# Altium schematic sheet Base IC.SchDoc
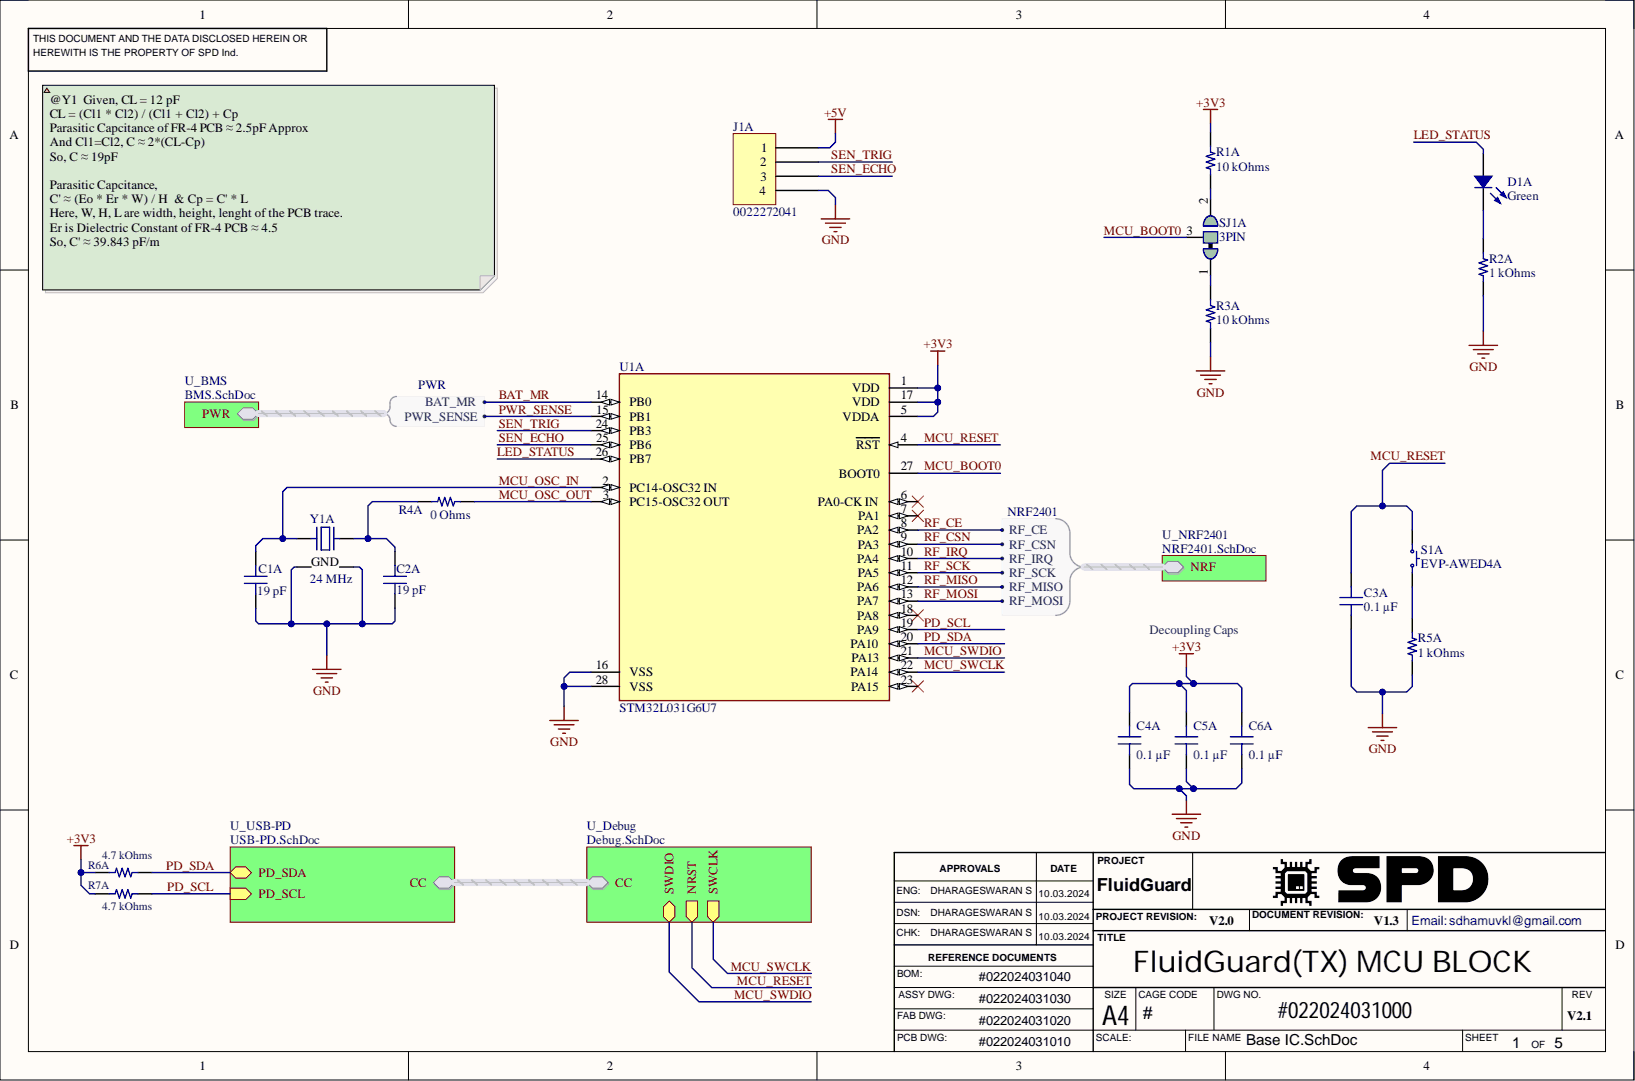
[diagram p0: Base IC.SchDoc]

## Parts
C1A  19 pF  [CAP 0402_1005]
C2A  19 pF  [CAP 0402_1005]
C3A  0.1 µF  [CAP 0402_1005]
C4A  0.1 µF  [CAP 0402_1005]
C5A  0.1 µF  [CAP 0402_1005]
C6A  0.1 µF  [CAP 0402_1005]
D1A  Green  [LED 0402_1005 GREEN]
J1A  0022272041  [MOLEX KK 0022272041]
R1A  10 kOhms  [RES 0402_1005]
R2A  1 kOhms  [RES 0402_1005]
R3A  10 kOhms  [RES 0402_1005]
R4A  0 Ohms  [RES 0402_1005]
R5A  1 kOhms  [RES 0402_1005]
R6A  4.7 kOhms  [RES 0402_1005]
R7A  4.7 kOhms  [RES 0402_1005]
S1A  EVP-AWED4A  [PANASONIC EVPAW]
SJ1A  3PIN  [Rounded Jumper Joint 3-pin]
U1A  STM32L031G6U7  [FP-UFQFPN28-A0B0-MFG]
Y1A  24 MHz  [EPSON TSX-3225]

## Nets
+3V3: C4A.2 C5A.2 C6A.2 R1A.2 R6A.2 R7A.2 U1A.1(VDD) U1A.5(VDDA) U1A.17(VDD)
+5V: J1A.1
GND: C1A.1 C2A.1 C3A.1 C4A.1 C5A.1 C6A.1 J1A.4 R2A.1 R3A.1 R5A.1 U1A.16(VSS) U1A.28(VSS) Y1A.2 Y1A.4(GND)
BAT_MR: U1A.14(PB0)
LED_STATUS: D1A.1 U1A.26(PB7)
MCU_BOOT0: SJ1A.3 U1A.27(BOOT0)
MCU_OSC_IN: C1A.2 U1A.2(PC14-OSC32_IN) Y1A.1
MCU_OSC_OUT: R4A.2 U1A.3(PC15-OSC32_OUT)
MCU_RESET: C3A.2 S1A.1 U1A.4(RST)
MCU_SWCLK: U1A.22(PA14)
MCU_SWDIO: U1A.21(PA13)
PD_SCL: R7A.1 U1A.19(PA9)
PD_SDA: R6A.1 U1A.20(PA10)
PWR_SENSE: U1A.15(PB1)
RF_CE: U1A.8(PA2)
RF_CSN: U1A.9(PA3)
RF_IRQ: U1A.10(PA4)
RF_MISO: U1A.12(PA6)
RF_MOSI: U1A.13(PA7)
RF_SCK: U1A.11(PA5)
SEN_ECHO: J1A.3 U1A.25(PB6)
SEN_TRIG: J1A.2 U1A.24(PB3)
NetC2A_2: C2A.2 R4A.1 Y1A.3
NetD1A_2: D1A.2 R2A.2
NetR1A_1: R1A.1 SJ1A.2
NetR3A_2: R3A.2 SJ1A.1
NetR5A_2: R5A.2 S1A.2
NetU1A_6: U1A.6(PA0-CK_IN)
NetU1A_7: U1A.7(PA1)
NetU1A_18: U1A.18(PA8)
NetU1A_23: U1A.23(PA15)
CC.CC1:
CC.CC2:

## Library data of the parts
C1A C2A = GJM1555C1H190GB01D: CAP CER 19PF 50VDC 0402 C0G 2%; Manufacturer: Murata Electronics; Database Table Name: altium.Capacitors-Ceramic-0402; id: 5279; Temperature Coefficient: C0G, NP0; Voltage - Rated: 50V; Applications: RF, Microwave, High Frequency; Capacitance: 19 pF; Component Kind: Standard; ComponentLink1Description: Datasheet; ComponentLink1URL: https://search.murata.co.jp/Ceramy/image/img/A01X/G101/ENG/GJM1555C1H190GB01-01A.pdf; ComponentLink2Description: DigiKey Link; ComponentLink2URL: https://www.digikey.com/en/products/detail/murata-electronics/GJM1555C1H190GB01D/16033843; Device Package: 0402 (1005 Metric); Features: High Q, Low Loss; LastUpdated: 2023-01-03T20:03:22.137; Lifecycle Status: Active; Minimum Order: 1; Mounting Type: Surface Mount, MLCC; Operating Temperature: -55°C ~ 125°C; Package / Case: 0402 (1005 Metric); Packaging: TapeAndReel; Part Number: GJM1555C1H190GB01D; Part Status: Active; PartId: 41775; Price: 0.02; Series: GJM; Size / Dimension: 0.039" L x 0.020" W (1.00mm x 0.50mm); Supplier 1: DigiKey; Supplier Part Number 1: 490-GJM1555C1H190GB01DCT-ND; Thickness (Max): 0.022" (0.55mm); Tolerance: ±2%; Value: 19 pF; Library Name: Postgres Altium Library - altium_library.DbLib
C3A C4A C5A C6A = CL05B104KP5VPNC: CAP CER 100NF 10V X7R 0402; Manufacturer: Samsung Electro-Mechanics; Database Table Name: altium.Capacitors-Ceramic-0402; id: 7736; Tolerance: ±10%; Applications: Automotive; Capacitance: 0.1 µF; Component Kind: Standard; ComponentLink1Description: Datasheet; ComponentLink1URL: https://mm.digikey.com/Volume0/opasdata/d220001/medias/docus/5458/CL05B104KP5VPNC_Spec.pdf; ComponentLink2Description: DigiKey Link; ComponentLink2URL: https://www.digikey.com/en/products/detail/samsung-electro-mechanics/CL05B104KP5VPNC/20498482; Device Package: 0402 (1005 Metric); LastUpdated: 2023-11-05T20:02:55.743; Lifecycle Status: Active; Minimum Order: 1; Mounting Type: Surface Mount, MLCC; Operating Temperature: -55°C ~ 125°C; Package / Case: 0402 (1005 Metric); Packaging: TapeAndReel; Part Number: CL05B104KP5VPNC; PartId: 56690; Price: 0.01; Ratings: AEC-Q200; Series: CL; Size / Dimension: 0.039" L x 0.020" W (1.00mm x 0.50mm); Supplier 1: DigiKey; Supplier Part Number 1: 1276-CL05B104KP5VPNCCT-ND; Temperature Coefficient: X7R; Thickness (Max): 0.022" (0.55mm); Value: 0.1 µF; Voltage - Rated: 10V; Library Name: Postgres Altium Library - altium_library.DbLib
D1A = HSMG-C280: LED GREEN DIFFUSED 0402 SMD; Manufacturer: Broadcom Limited; Configuration: Standard; Current - Test: 20mA; Database Table Name: altium.LED-Indication; id: 697; Lens Color: White; Lens Size: 1.00mm x 0.50mm; Lens Style: Rectangle with Flat Top; Millicandela Rating: 15mcd; Viewing Angle: 130°; Voltage - Forward (Vf) (Typ): 2.2V; Wavelength - Dominant: 572nm; Wavelength - Peak: 570nm; Color: Green; Component Kind: Standard; ComponentLink1Description: Datasheet; ComponentLink1URL: https://docs.broadcom.com/docs/AV02-0602EN; ComponentLink2Description: DigiKey Link; ComponentLink2URL: https://www.digikey.com/en/products/detail/broadcom-limited/HSMG-C280/1235358; Device Package: 0402 (1005 Metric); Height (Max): 0.40mm; LastUpdated: 2023-01-02T20:56:26.750; Lens Transparency: Diffused; Lifecycle Status: Active; Minimum Order: 1; Mounting Type: Surface Mount; Package / Case: 0402 (1005 Metric); Packaging: TapeAndReel; Part Number: HSMG-C280; Part Status: Active; PartId: 697; Price: 0.08; Series: -; Size / Dimension: 1.00mm L x 0.50mm W; Supplier 1: DigiKey; Supplier Device Package: 0402; Supplier Part Number 1: 516-3066-1-ND; Value: Green; Library Name: Postgres Altium Library - altium_library.DbLib
J1A = 0022272041: CONN HEADER VERT 4POS 2.54MM; Manufacturer: Molex; Contact Length - Mating: 0.295" (7.49mm); Contact Length - Post: 0.140" (3.56mm); Database Table Name: altium.Connectors-RectangularHeadersMalePins; id: 78; Material Flammability Rating: UL94 V-0; Number Of Positions: 4; Number Of Positions Loaded: All; Overall Contact Length: 0.560" (14.22mm); Pitch - Mating: 0.100" (2.54mm); Shrouding: Shrouded - 1 Wall; Style: Board to Cable/Wire; Component Kind: Standard; ComponentLink1Description: Datasheet; ComponentLink1URL: https://www.molex.com/pdm_docs/sd/022272041_sd.pdf; ComponentLink2Description: DigiKey Link; ComponentLink2URL: http://digikey.com/product-detail/en/molex/0022272041/WM4113-ND/1130579; Connector Type: Header; Contact Finish - Mating: Tin; Contact Finish - Post: Tin; Contact Finish Thickness - Mating: 100.0µin (2.54µm); Contact Material: Brass; Contact Shape: Square; Contact Type: Male Pin; Fastening Type: Friction Lock; Insulation Color: Natural; Insulation Height: 0.461" (11.70mm); Insulation Material: Polyamide (PA), Nylon; LastUpdated: 2020-01-12T23:52:05.287; Lifecycle Status: Active; Minimum Order: 1; Mounting Type: Through Hole; Number Of Rows: 1; Packaging: Bulk; Part Number: 0022272041; Part Status: Active; PartId: 78; Price: 0.40; Series: KK 6410; Supplier 1: DigiKey; Supplier Part Number 1: WM4113-ND; Termination: Solder; Library Name: Postgres Altium Library - altium_library.DbLib
R1A R3A = AA0402FR-0710KL: RES SMD 10K OHM 1% 1/16W 0402; Manufacturer: Yageo; Database Table Name: altium.Resistors-SurfaceMount-0402; id: 10610; Power (Watts): 0.063W, 1/16W; Temperature Coefficient: ±150ppm/°C; Component Kind: Standard; ComponentLink1Description: Datasheet; ComponentLink1URL: https://www.yageo.com/upload/media/product/productsearch/datasheet/rchip/PYu-AA_51_RoHS_L_3.pdf; ComponentLink2Description: DigiKey Link; ComponentLink2URL: https://www.digikey.com/en/products/detail/yageo/AA0402FR-0710KL/5887729; Composition: Thick Film; Device Package: 0402 (1005 Metric); Features: Anti-Sulfur, Automotive AEC-Q200, Moisture Resistant; Height - Seated (Max): 0.015" (0.37mm); LastUpdated: 2023-01-02T20:20:10.303; Lifecycle Status: Active; Minimum Order: 1; Number Of Terminations: 2; Operating Temperature: -55°C ~ 155°C; Package / Case: 0402 (1005 Metric); Packaging: TapeAndReel; Part Number: AA0402FR-0710KL; Part Status: Active; PartId: 59190; Price: 0.01; Ratings: AEC-Q200; Resistance: 10 kOhms; Series: AA; Size / Dimension: 0.039" L x 0.020" W (1.00mm x 0.50mm); Supplier 1: DigiKey; Supplier Device Package: 0402; Supplier Part Number 1: 13-AA0402FR-0710KLCT-ND; Tolerance: ±1%; Value: 10 kOhms; Library Name: Postgres Altium Library - altium_library.DbLib
R2A R5A = AA0402FR-071KL: RES SMD 1K OHM 1% 1/16W 0402; Manufacturer: Yageo; Database Table Name: altium.Resistors-SurfaceMount-0402; id: 10598; Power (Watts): 0.063W, 1/16W; Temperature Coefficient: ±150ppm/°C; Component Kind: Standard; ComponentLink1Description: Datasheet; ComponentLink1URL: https://www.yageo.com/upload/media/product/productsearch/datasheet/rchip/PYu-AA_51_RoHS_L_3.pdf; ComponentLink2Description: DigiKey Link; ComponentLink2URL: https://www.digikey.com/en/products/detail/yageo/AA0402FR-071KL/5887878; Composition: Thick Film; Device Package: 0402 (1005 Metric); Features: Anti-Sulfur, Automotive AEC-Q200, Moisture Resistant; Height - Seated (Max): 0.015" (0.37mm); LastUpdated: 2023-01-02T20:20:10.210; Lifecycle Status: Active; Minimum Order: 1; Number Of Terminations: 2; Operating Temperature: -55°C ~ 155°C; Package / Case: 0402 (1005 Metric); Packaging: TapeAndReel; Part Number: AA0402FR-071KL; Part Status: Active; PartId: 59178; Price: 0.01; Ratings: AEC-Q200; Resistance: 1 kOhms; Series: AA; Size / Dimension: 0.039" L x 0.020" W (1.00mm x 0.50mm); Supplier 1: DigiKey; Supplier Device Package: 0402; Supplier Part Number 1: 13-AA0402FR-071KLCT-ND; Tolerance: ±1%; Value: 1 kOhms; Library Name: Postgres Altium Library - altium_library.DbLib
R4A = AC0402JR-070RL: RES SMD 0 OHM JUMPER 1/16W 0402; Manufacturer: Yageo; Database Table Name: altium.Resistors-SurfaceMount-0402; id: 9339; Power (Watts): 0.063W, 1/16W; Component Kind: Standard; ComponentLink1Description: Datasheet; ComponentLink1URL: https://www.yageo.com/upload/media/product/productsearch/datasheet/rchip/PYu-AC_51_RoHS_L_7.pdf; ComponentLink2Description: DigiKey Link; ComponentLink2URL: https://www.digikey.com/en/products/detail/yageo/AC0402JR-070RL/2827807; Composition: Thick Film; Device Package: 0402 (1005 Metric); Features: Automotive AEC-Q200, Moisture Resistant; Height - Seated (Max): 0.015" (0.37mm); LastUpdated: 2023-01-02T20:20:32.243; Lifecycle Status: Active; Minimum Order: 1; Number Of Terminations: 2; Operating Temperature: -55°C ~ 155°C; Package / Case: 0402 (1005 Metric); Packaging: CutTape; Part Number: AC0402JR-070RL; Part Status: Active; PartId: 20617; Price: 0.01; Ratings: AEC-Q200; Resistance: 0 Ohms; Series: AC; Size / Dimension: 0.039" L x 0.020" W (1.00mm x 0.50mm); Supplier 1: DigiKey; Supplier Device Package: 0402; Supplier Part Number 1: 311-0.0LBCT-ND; Tolerance: Jumper; Value: 0 Ohms; Library Name: Postgres Altium Library - altium_library.DbLib
R6A R7A = AC0402FR-074K7L: RES SMD 4.7K OHM 1% 1/16W 0402; Manufacturer: Yageo; Database Table Name: altium.Resistors-SurfaceMount-0402; id: 4996; Power (Watts): 0.063W, 1/16W; Temperature Coefficient: ±100ppm/°C; Component Kind: Standard; ComponentLink1Description: Datasheet; ComponentLink1URL: https://www.yageo.com/upload/media/product/productsearch/datasheet/rchip/PYu-AC_51_RoHS_L_7.pdf; ComponentLink2Description: DigiKey Link; ComponentLink2URL: https://www.digikey.com/en/products/detail/yageo/AC0402FR-074K7L/5895396; Composition: Thick Film; Device Package: 0402 (1005 Metric); Features: Automotive AEC-Q200, Moisture Resistant; Height - Seated (Max): 0.015" (0.37mm); LastUpdated: 2023-01-02T20:21:09.847; Lifecycle Status: Active; Minimum Order: 1; Number Of Terminations: 2; Operating Temperature: -55°C ~ 155°C; Package / Case: 0402 (1005 Metric); Packaging: CutTape; Part Number: AC0402FR-074K7L; Part Status: Active; PartId: 12051; Price: 0.01; Ratings: AEC-Q200; Resistance: 4.7 kOhms; Series: AC; Size / Dimension: 0.039" L x 0.020" W (1.00mm x 0.50mm); Supplier 1: DigiKey; Supplier Device Package: 0402; Supplier Part Number 1: YAG3497CT-ND; Tolerance: ±1%; Value: 4.7 kOhms; Library Name: Postgres Altium Library - altium_library.DbLib
S1A = EVP-AWED4A: 3.0MM X 2.0MM / 3.3N / 0.15MM ST; Manufacturer: Panasonic Electronic Components; Actuator Height Off Pcb, Vertical: 0.60mm; Actuator Orientation: Top Actuated; Actuator Type: Standard; Circuit: SPST-NO; Contact Rating @ Voltage: 0.02A @ 15VDC; Database Table Name: altium.Switches-Tactile; id: 5; Illumination: Non-Illuminated; Ingress Protection: IP67 - Dust Tight, Waterproof; Operating Force: 330gf; Outline: 3.00mm x 2.00mm; Switch Function: Off-Mom; Component Kind: Standard; ComponentLink1Description: Datasheet; ComponentLink1URL: https://industrial.panasonic.com/ac/cdn/e/control/switch/light-touch/catalog/sw_lt_eng_3020s.pdf; ComponentLink2Description: DigiKey Link; ComponentLink2URL: https://www.digikey.com/en/products/detail/panasonic-electronic-components/EVP-AWED4A/8568275; LastUpdated: 2023-01-02T21:07:29.980; Lifecycle Status: Active; Minimum Order: 1; Mounting Type: Surface Mount; Operating Temperature: -40°C ~ 85°C; Packaging: TapeAndReel; Part Number: EVP-AWED4A; Part Status: Active; Price: 0.16; Series: EVPAW; Supplier 1: DigiKey; Supplier Part Number 1: P123440CT-ND; Termination Style: SMD (SMT) Tab; Library Name: Postgres Altium Library - altium_library.DbLib
SJ1A = Rounded Jumper Joint 3-pin: Component Kind: Standard; Library Name: Jumper-SMD.IntLib
U1A = CMP-12105-000084-1: IC MCU 32BIT 32KB FLASH 28UFQFPN; Core Architecture: ARM; Frequency: 32MHz; Interface: I2C; Max Power Dissipation: 167mW; Max Supply Voltage: 3.6V; Min Supply Voltage: 1.8V; Number of I/Os: 21; Number of Timers/Counters: 4; Operating Supply Voltage: 3.3V; Oscillator Type: Internal; Peripherals: Brown-out Detect/Reset; RAM Size: 1KB; Watchdog Timer: Yes; Component Kind: Standard; Lead Free: Lead Free; Library Name: STM32L031G6U7.IntLib; Max Frequency: 32MHz; Max Operating Temperature: 105°C; Memory Size: 32KB; Memory Type: FLASH; Min Operating Temperature: -40°C; Mount: Surface Mount; Number of Pins: 28; Number of Programmable I/O: 21
Y1A = TSX-3225 24.0000MF15X-AC3: CRYSTAL 24.0000MHZ 9PF SMD; Manufacturer: EPSON; Database Table Name: altium.Oscillators-Crystals; Esr (Equivalent Series Resistance): 40 Ohms; Frequency Stability: ±15ppm; Frequency Tolerance: ±10ppm; id: 441; Operating Mode: Fundamental; Component Kind: Standard; ComponentLink1Description: Datasheet; ComponentLink1URL: https://support.epson.biz/td/api/doc_check.php?dl=brief_TSX-3225&lang=en; ComponentLink2Description: DigiKey Link; ComponentLink2URL: https://www.digikey.com/en/products/detail/epson/TSX-3225-24-0000MF15X-AC3/1825444; Frequency: 24 MHz; Height - Seated (Max): 0.024" (0.60mm); LastUpdated: 2023-01-02T20:58:19.840; Lifecycle Status: Active; Load Capacitance: 9pF; Minimum Order: 1; Mounting Type: Surface Mount; Operating Temperature: -40°C ~ 85°C; Package / Case: 4-SMD, No Lead; Packaging: TapeAndReel; Part Number: TSX-3225 24.0000MF15X-AC3; Part Status: Active; PartId: 441; Price: 0.44; Series: TSX-3225; Size / Dimension: 0.126" L x 0.098" W (3.20mm x 2.50mm); Supplier 1: DigiKey; Supplier Part Number 1: SER3635CT-ND; Type: MHz Crystal; Value: 24 MHz; Library Name: Postgres Altium Library - altium_library.DbLib
